# Altium schematic sheet connector.SchDoc
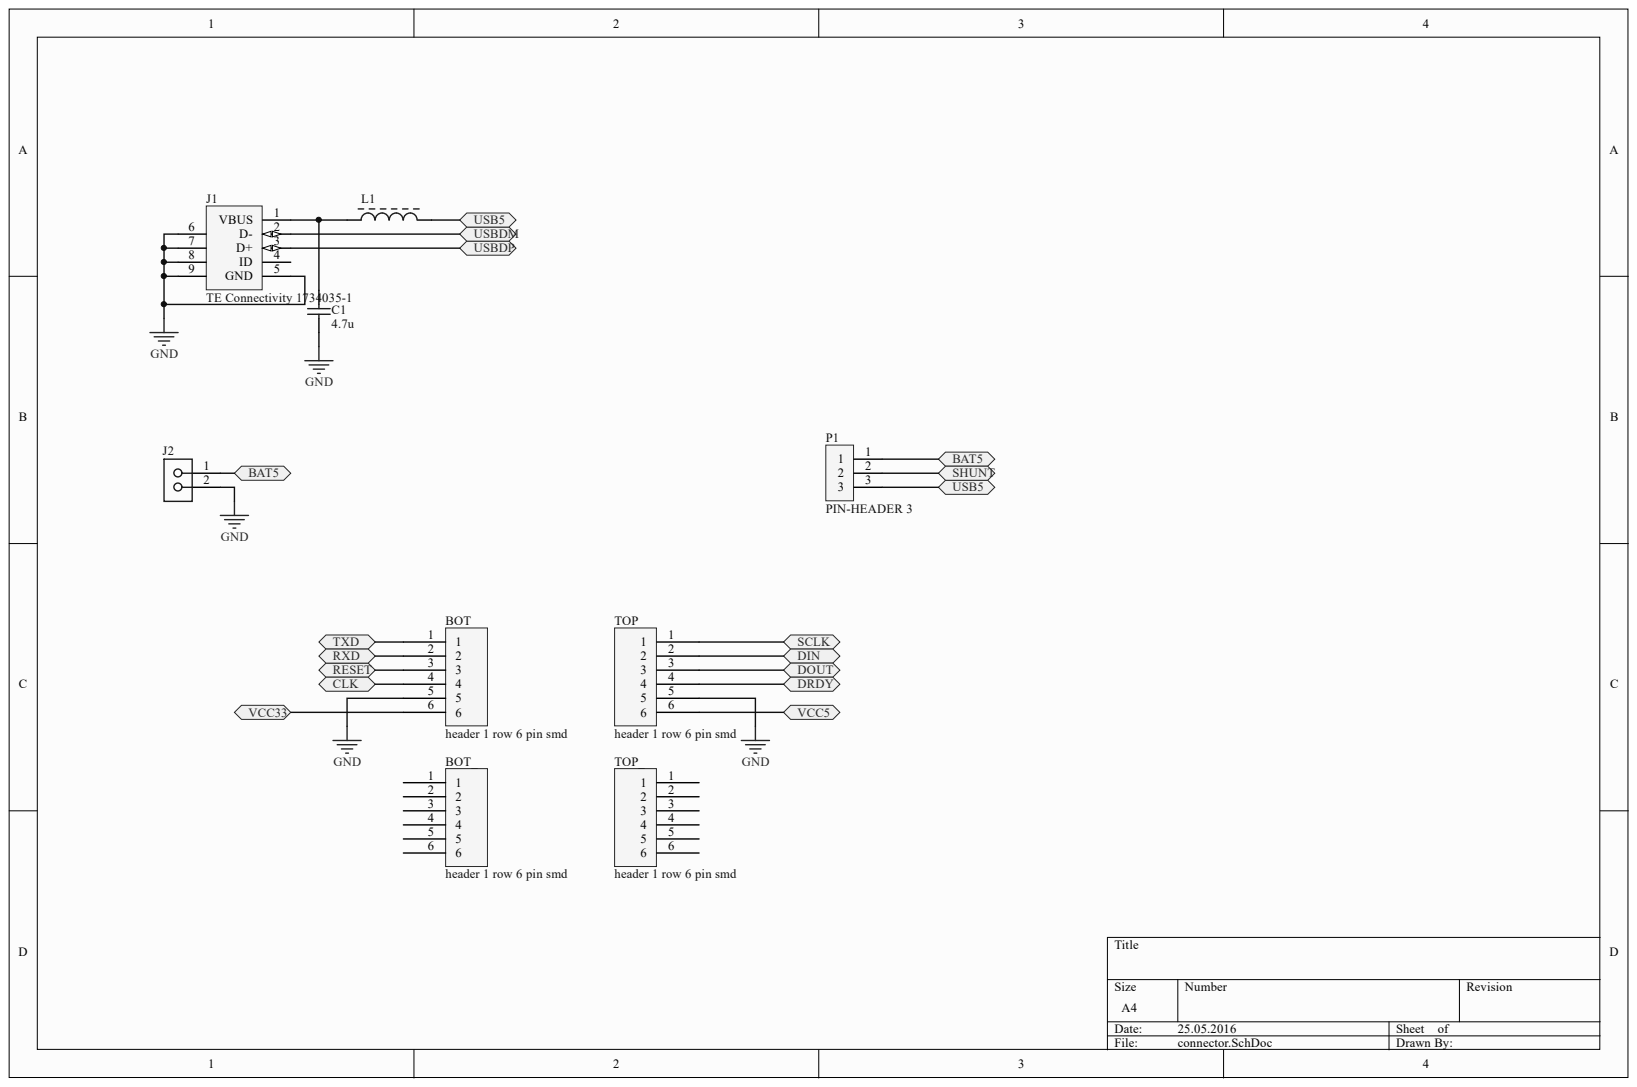
[diagram p0: connector.SchDoc]

## Parts
BOT  header 1 row 6 pin smd  [PCB-nv1amr6icfejtfl4tawb-1]
BOT_  header 1 row 6 pin smd  [PCB-nv1amr6icfejtfl4tawb-1]
C1  4.7u  [CAPC2013X145X50LL20T25]
J1  TE Connectivity 1734035-1  [PCB-92xbr658ff5005b6v25i-1]
J2  (no value)  [PCB-d8chgxfsn9441hp4am0k-1]
L1  (no value)  [CAPC1608X90X35NL15T15]
P1  PIN-HEADER 3  [PCB-aye1kopuipom6vatitq4-1]
TOP  header 1 row 6 pin smd  [PCB-nv1amr6icfejtfl4tawb-1]
TOP_  header 1 row 6 pin smd  [PCB-nv1amr6icfejtfl4tawb-1]

## Ports
BAT5, CLK, DIN, DOUT, DRDY, RESET, RXD, SCLK, SHUNT, TXD, USB5, USBDM, USBDP, VCC5, VCC33

## Nets
GND: BOT.5 C1.1 J1.5(GND) J1.6 J1.7 J1.8 J1.9 J2.2 TOP.5
BAT5: J2.1 P1.1 ; port BAT5
CLK: BOT.4 ; port CLK
DIN: TOP.2 ; port DIN
DOUT: TOP.3 ; port DOUT
DRDY: TOP.4 ; port DRDY
RESET: BOT.3 ; port RESET
RXD: BOT.2 ; port RXD
SCLK: TOP.1 ; port SCLK
SHUNT: P1.2 ; port SHUNT
TXD: BOT.1 ; port TXD
USB5: L1.2 P1.3 ; port USB5
USBDM: J1.2(D-) ; port USBDM
USBDP: J1.3(D+) ; port USBDP
VCC5: TOP.6 ; port VCC5
VCC33: BOT.6 ; port VCC33
NetC1_2: C1.2 J1.1(VBUS) L1.1

Not connected: BOT_ 1 2 3 4 5 6; J1 4(ID); TOP_ 1 2 3 4 5 6

## Library data of the parts
BOT BOT_ TOP TOP_ = CMP-0wbak55bjo2817zc0o2k-2: component monted on one layer; Component Kind: Standard; CustomComponentID: 0wbak55bjo2817zc0o2k; Library Name: CommunityVault
C1 = Kemet C0805C104J5RAC7800-2: 0805 X7R ceramic capacitor, 50V 0.1uF; Capacitance: 1e-07 F; Capacitance Tolerance: ±5%; Lead-Free Status: R; Voltage Rating (DC): 50.0 V; Case/Package: 0805; Component Kind: Standard; Dielectric Characteristic: X7R; Dielectric Material: Ceramic; Library Name: FavoritesLibrary; Mounting Style: Surface Mount; OctopartID: 9cb2558377904375; Octopart Url: https://octopart.com/c0805c104j5rac7800-kemet-3919428; RoHS: Compliant
J1 = CMP-778005-8: USB Connectors 5P MINI TYPE B RECPT SMT WITH POSTS; ELV Compliant: Compliant; Gender: Female; Lead-Free Status: Lead Free; Number of Contacts: 5; Component Kind: Standard; Contact Material: Phosphor Bronze; Flammability Rating: UL 94 V-0; Housing Color: Black; Housing Material: Thermoplastic; Library Name: CommunityVault; Lifecycle Status: Active; Manufacturer Part Number: 1734035-1; Number of Positions: 5; OctopartID: 9a20517374d345e7; Octopart Url: https://octopart.com/1734035-1-te+connectivity-39439253; Orientation: Right Angle; Packaging: Tray; REACH SVHC Compliance: No SVHC; RoHS: Compliant; Size-Height: 0.0039 m; Size-Length: 0.00845 m; Size-Width: 0.0077 m
J2 = TE Connectivity 2029030-2-1: Low Profile MATE-N-LOK 2 Position 3 mm Pitch Right Angle Surface Mount Header; Current Rating: 5.0 A; ELV Compliant: Compliant; Gender: Male; Lead-Free Status: Lead Free; Number of Contacts: 2; Voltage Rating (AC): 250.0 V; Color: Black; Component Kind: Standard; Contact Material: Brass; Contact Plating: Tin; Flammability Rating: UL 94 V-0; Housing Color: Black; Housing Material: Nylon; Library Name: FavoritesLibrary; Lifecycle Status: Active; Manufacturer Part Number: 2029030-2; Material: Nylon; Mounting Style: Surface Mount, PCB; OctopartID: 1e18d591d2b6c1df; Octopart URL: http://octopart.com/2029030-2-te+connectivity-42199496; Orientation: Right Angle; Packaging: Reel; REACH SVHC Compliance: No SVHC; RoHS: Compliant
L1 = TDK MPZ1608S601A-1: MPZ Series 0603 600 Ohm 1 A 0.15 Ohm DCR Surface Mount Power Line Chip Bead; Case/Package (SI): 1608; Current Rating: 0.5 A; Frequency: 100000000.0 Hz; Lead-Free Status: Lead Free; Resistance: 0.15 ?; Shielding: No; Case/Package: 0603; Component Kind: Standard; Library Name: FavoritesLibrary; Manufacturer Part Number: MPZ1608S601A; Mounting Style: Surface Mount; Number of Pins: 2; OctopartID: 2831e13e93fff7f6; Octopart URL: http://octopart.com/mpz1608s601a-tdk-368296; Packaging: Tape & Reel (TR), Cut Tape (CT); REACH SVHC Compliance: No SVHC; RoHS: Compliant; Size-Height: 0.0008 m; Size-Length: 0.0016 m; Size-Width: 0.0008 m
P1 = PIN-HEADER 3-9: AMPMODU 3 Position Through-Hole Single Row 0.1 Inch Straight Breakaway Header; Manufacturer Part Number: 5-146281-3; ELV Compliant: Compliant; Gender: Male; Lead-Free Status: Lead Free; Number of Contacts: 3; Component Kind: Standard; Contact Material: Copper Alloy; Contact Plating: Tin, Tin over Nickel, Gold; Flammability Rating: UL 94 V-0; Housing Color: Black; Library Name: FavoritesLibrary; Lifecycle Status: Active; Mounting Style: PCB; OctopartID: 56249debc17089e3; Octopart URL: http://octopart.com/5-146281-3-te+connectivity-46510642; Orientation: Vertical; RoHS: Compliant
